# Altium schematic sheet Pulse_Generator.SchDoc
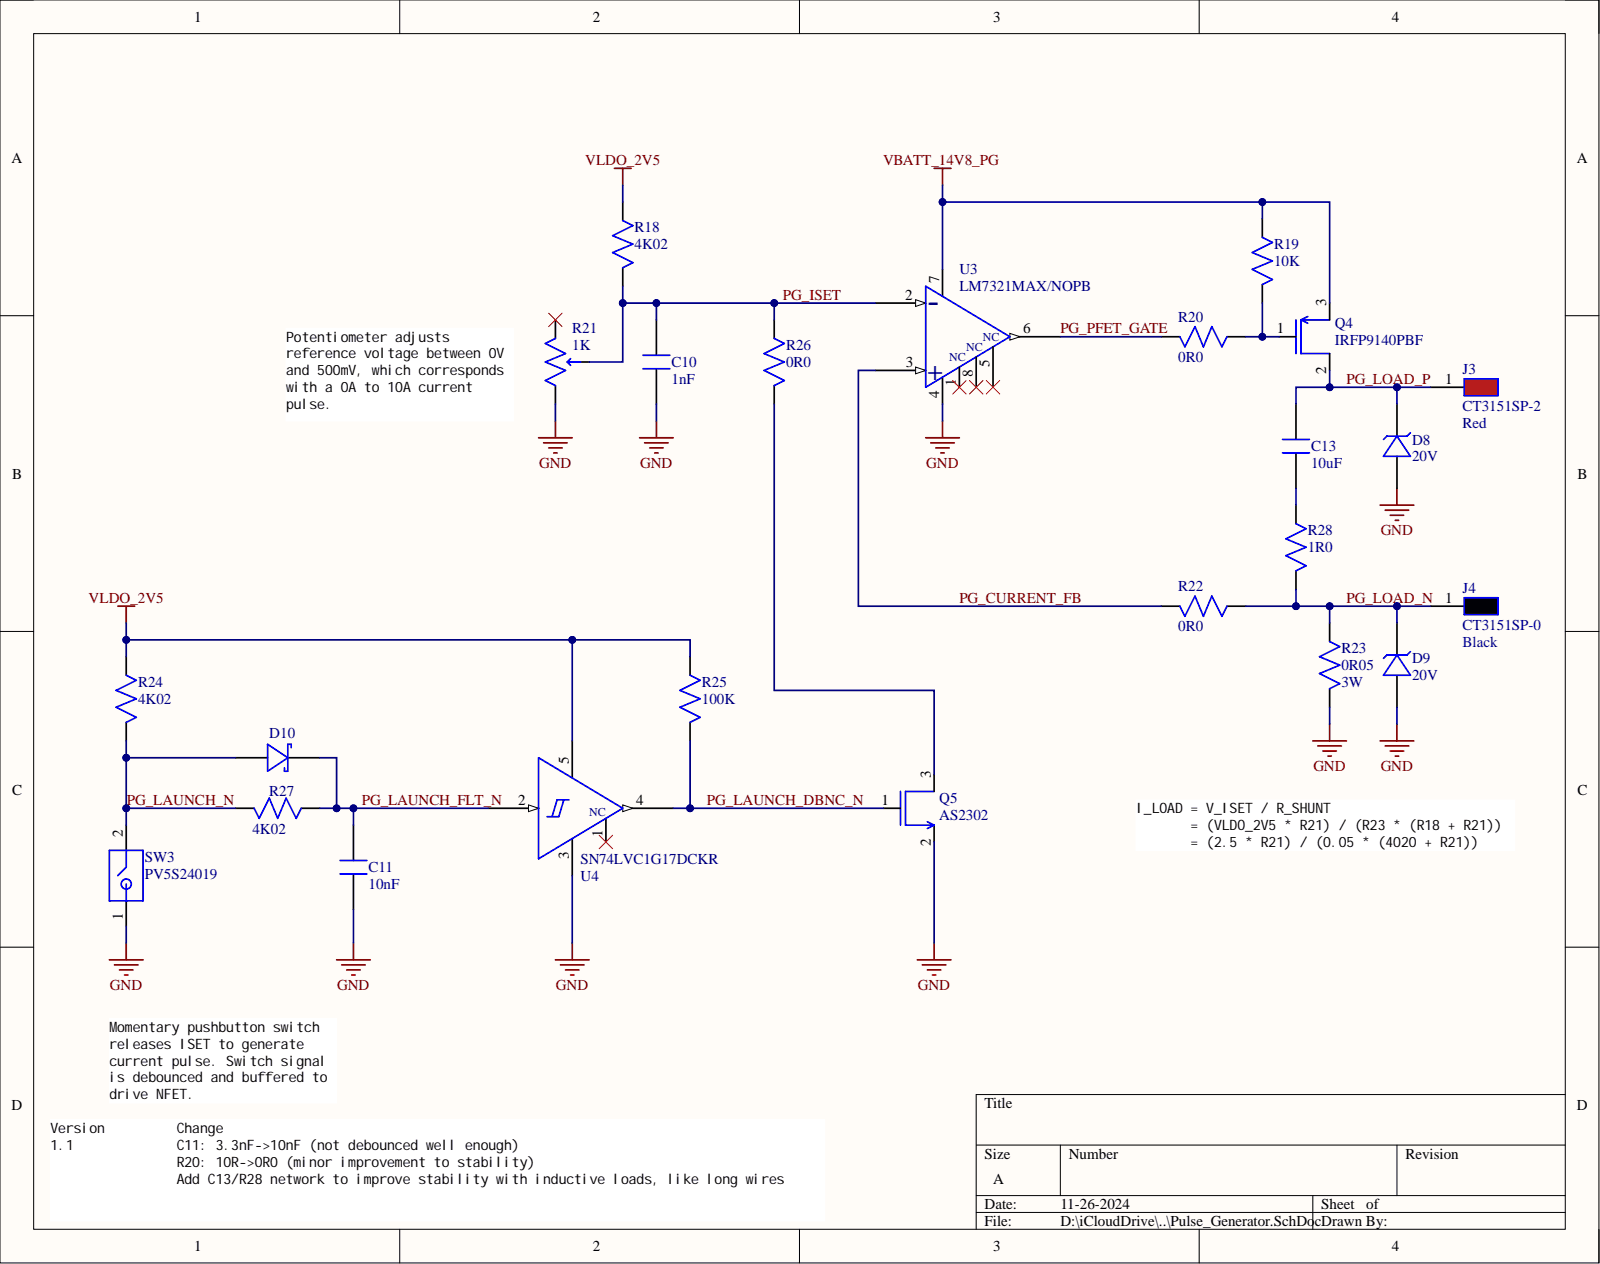
[diagram p0: Pulse_Generator.SchDoc]

## Parts
C10  Capacitance: 1nF  [0603_CAP]
C11  Capacitance: 10nF  [0603_CAP]  (hidden comment: CAP_0603_10nF)
C13  Capacitance: 10uF  [0805_CAP]  (hidden comment: CAP_0805_10uF)
D8  Reverse Standoff Voltage: 20V  [DO-214AC_SMA]
D9  Reverse Standoff Voltage: 20V  [DO-214AC_SMA]
D10  (no value)  [SOD-523]
J3  Colour: Red  Part Number: CT3151SP-2  [CONN_BANANA_RED_CT3151SP-2]
J4  Colour: Black  Part Number: CT3151SP-0  [CONN_BANANA_BLK_CT3151SP-0]
Q4  Part Number: IRFP9140PBF  [TO-247AC_NOHOLE]
Q5  Part Number: AS2302  [SOT-23-3]
R18  Resistance: 4K02  [0603_RES]
R19  Resistance: 10K  [0603_RES]
R20  Resistance: 0R0  [0603_RES]
R21  Resistance: 1K  [POT_PTV09A-4x15F-xxxx]
R22  Resistance: 0R0  [0603_RES]
R23  Power Rating: 3W  Resistance: 0R05  [RES_BR3FB50L0_0R05_1%]
R24  Resistance: 4K02  [0603_RES]
R25  Resistance: 100K  [0603_RES]
R26  Resistance: 0R0  [0603_RES]
R27  Resistance: 4K02  [0603_RES]
R28  Resistance: 1R0  [0805_RES]  (hidden comment: RES_0805_1R0)
SW3  Part Number: PV5S24019  [SW_PUSHBUTTON_PV5S24019]
U3  Part Number: LM7321MAX/NOPB  [SOIC-8_TI_PACKAGE_D]
U4  Part Number: SN74LVC1G17DCKR  [SOT-353]

## Nets
GND: C10.1 C11.1 D8.2 D9.2 Q5.2 R21.1 R23.1 SW3.1 U3.4 U4.3
VBATT_14V8_PG: Q4.3 R19.2 U3.7
VLDO_2V5: R18.2 R24.2 R25.2 U4.5
PG_CURRENT_FB: R22.1 U3.3(+)
PG_ISET: C10.2 R18.1 R21.2 R26.2 U3.2(-)
PG_LAUNCH_DBNC_N: Q5.1 R25.1 U4.4
PG_LAUNCH_FLT_N: C11.2 D10.1 R27.2 U4.2
PG_LAUNCH_N: D10.2 R24.1 R27.1 SW3.2
PG_LOAD_N: D9.1 J4.1 R22.2 R23.2 R28.1
PG_LOAD_P: C13.2 D8.1 J3.1 Q4.2
PG_PFET_GATE: R20.1 U3.6
NetC13_1: C13.1 R28.2
NetQ4_1: Q4.1 R19.1 R20.2
NetQ5_3: Q5.3 R26.1

Not connected: R21 3; U3 1(NC) 5(NC) 8(NC); U4 1(NC)

## Library data of the parts
C10 = CAP_0603_1nF: Manufacturer: KYOCERA AVX; Part Number: KGM15AR71H102KT; Dielectric: X7R; Tolerance: 10%; Voltage: 50V; Component Kind: Standard; Library Name: SharedPartsLib.SchLib
C11 = CAP_0603_10nF: Manufacturer: Samsung Electro-Mechanics; Part Number: CL10B103KB8NNNC; Dielectric: X7R; Tolerance: 10%; Voltage: 50V; Component Kind: Standard; Library Name: SharedPartsLib.SchLib
C13 = CAP_0805_10uF: Manufacturer: Yageo; Part Number: CC0805KKX5R5BB106; Tolerance: 10%; Voltage: 6.3V; Component Kind: Standard; Library Name: SharedPartsLib.SchLib
D8 D9 = DIODE_TVS_20V_UNIDIR: Manufacturer: Diodes Incorporated; Part Number: SMAJ20AQ-13-F; Component Kind: Standard; Package: DO-214AC_SMA; Library Name: SharedPartsLib.SchLib
D10 = DIODE_RB520S30T5G: Manufacturer: ON Semiconductor; Max Reverse Voltage: 30V; Component Kind: Standard; Package: SOD-523; Part Number: RB520S30T5G; Library Name: SharedPartsLib.SchLib
J3 = CONN_BANANA_RED_CT3151SP-2: Manufacturer: Cal Test Electronics; Component Kind: Standard; Library Name: SharedPartsLib.SchLib
J4 = CONN_BANANA_BLK_CT3151SP-2_1: Manufacturer: Cal Test Electronics; Component Kind: Standard; Library Name: SharedPartsLib.SchLib
Q4 = PFET_IRFP9140: Manufacturer: Vishay; Component Kind: Standard; Package: TO-247-3; Library Name: SharedPartsLib.SchLib
Q5 = NFET_AS2302: Manufacturer: ANBON SEMICONDUCTOR (INT'L) LIMITED; Component Kind: Standard; Package: SOT-23-3; Library Name: SharedPartsLib.SchLib
R18 R24 R27 = RES_0603_4K02: Manufacturer: Stackpole Electronics Inc; Part Number: RMCF0603FT4K02; Power Rating: 0.1W; Temperature Coefficient: 100ppm; Tolerance: 1%; Component Kind: Standard; Library Name: SharedPartsLib.SchLib
R19 = RES_0603_10K: Manufacturer: Stackpole Electronics Inc; Part Number: RMCF0603FT10K0; Power Rating: 0.1W; Temperature Coefficient: 100ppm; Tolerance: 1%; Component Kind: Standard; Library Name: SharedPartsLib.SchLib
R20 R22 R26 = RES_0603_0R0: Component Kind: Standard; Library Name: SharedPartsLib.SchLib
R21 = POT_1K_PTV09A-4015F-B102: Manufacturer: Bourns Inc.; Power Rating: 0.05W; Tolerance: 20%; Component Kind: Standard; Part Number: PTV09A-4015F-B102; Library Name: SharedPartsLib.SchLib
R23 = RES_BR3FB50L0_0R05_1%: Manufacturer: Stackpole Electronics; Tolerance: 1%; Component Kind: Standard; Part Number: BR3FB50L0; Library Name: SharedPartsLib.SchLib
R25 = RES_0603_100K: Manufacturer: Stackpole; Part Number: RMCF0603FT100K; Power Rating: 0.1W; Temperature Coefficient: 100ppm; Tolerance: 1%; Component Kind: Standard; Library Name: SharedPartsLib.SchLib
R28 = RES_0805_1R0: Manufacturer: Stackpole Electronics Inc; Part Number: RMCF0805FT1R00; Power Rating: 0.125W; Temperature Coefficient: 200ppm; Tolerance: 1%; Component Kind: Standard; Library Name: SharedPartsLib.SchLib
SW3 = SW_PUSHBUTTON_PV5S24019: Manufacturer: E-Switch; Component Kind: Standard; Library Name: SharedPartsLib.SchLib
U3 = LM7321: Manufacturer: Texas Instruments; Component Kind: Standard; Package: SOIC-8; Library Name: SharedPartsLib.SchLib
U4 = SN74LVC1G17: Manufacturer: Texas Instruments; Component Kind: Standard; Package: SOT-353; Library Name: SharedPartsLib.SchLib
